# Altium schematic sheet Sheet1.SchDoc(Sheet1)
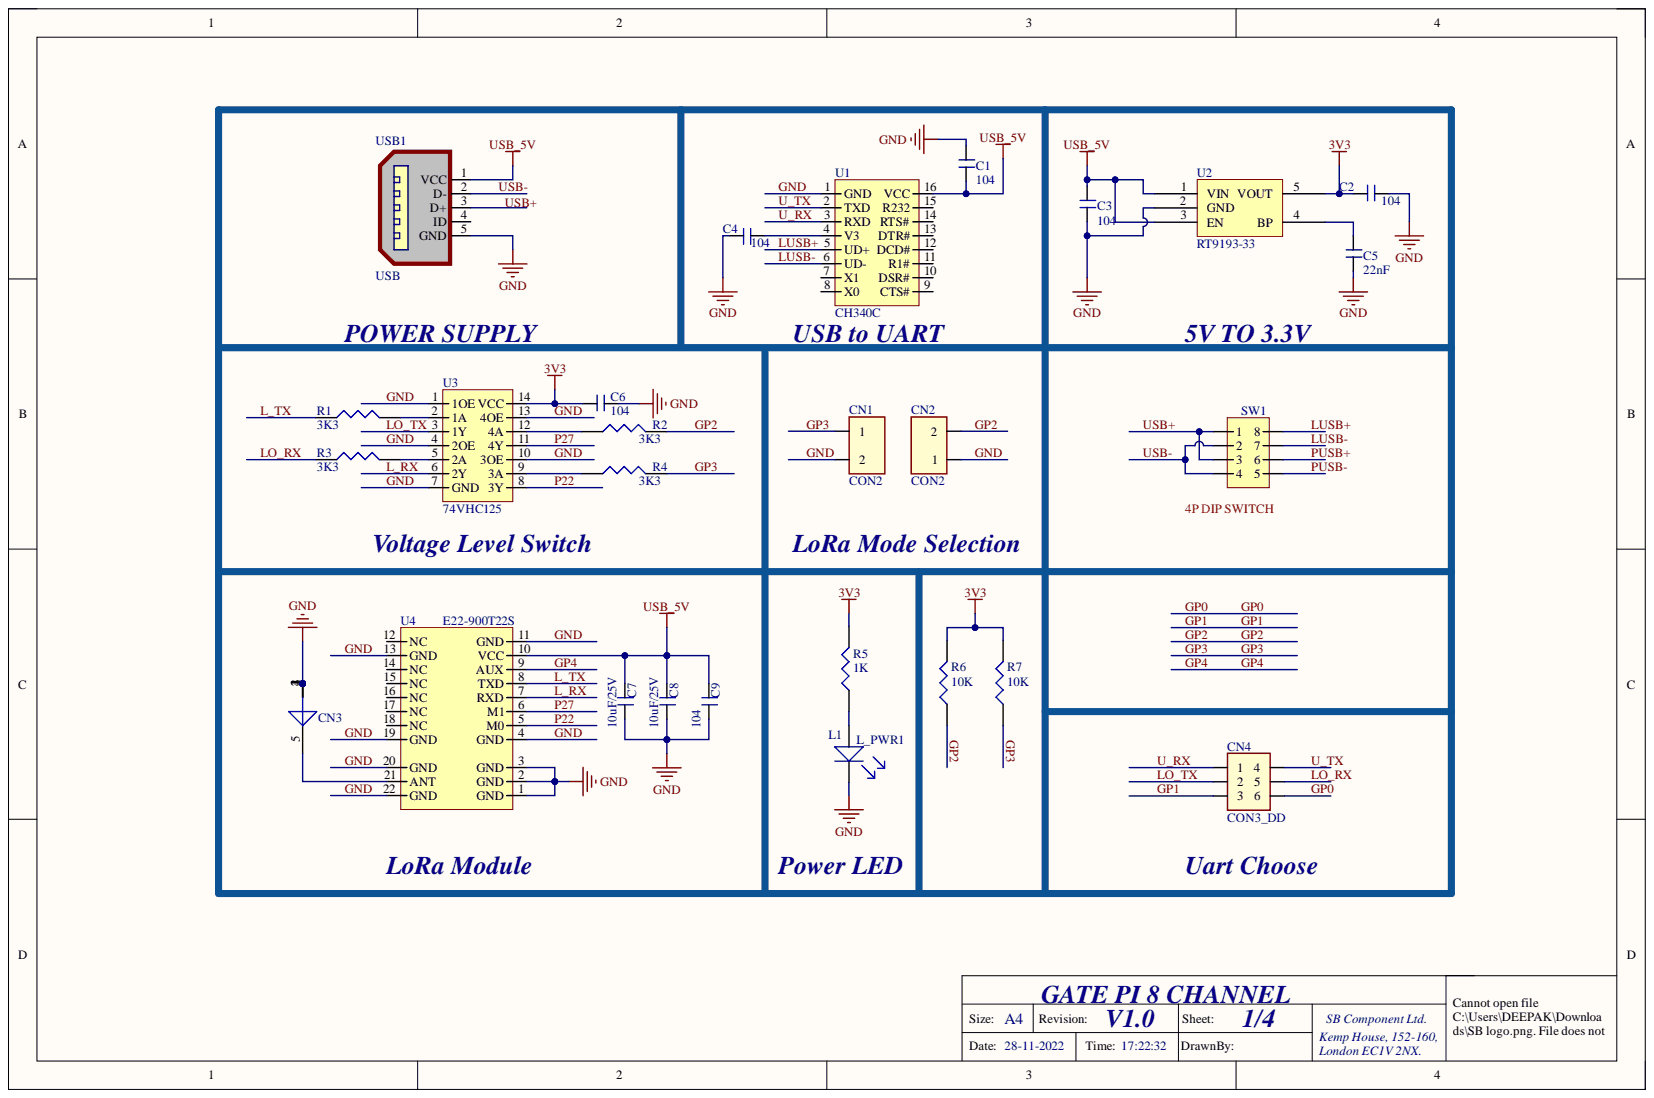
[diagram p0: Sheet1.SchDoc]

## Parts
C1  104  [CAP_0603_NONPOLAR]
C2  104  [CAP_0603_NONPOLAR]
C3  104  [CAP_0603_NONPOLAR]
C4  104  [CAP_0603_NONPOLAR]
C5  22nF  [CAP_0603_NONPOLAR]
C6  104  [CAP_0603_NONPOLAR]
C7  10uF/25V  [CAP_0603_NONPOLAR]
C8  10uF/25V  [CAP_0603_NONPOLAR]
C9  104  [CAP_0603_NONPOLAR]
CN1  CON2  [CON2_BJ]
CN2  CON2  [CON2_BJ]
CN3  SMA  CONNECTOR  [SMA_CONNECTOR]  (value not drawn)
CN4  CON3_DD  [CON3_BJ_DD]
L1  L_PWR1  [LED_0603_neg]
R1  3K3  [0603]
R2  3K3  [0603]
R3  3K3  [0603]
R4  3K3  [0603]
R5  1K  [0603]
R6  10K  [0603]
R7  10K  [0603]
SW1  SW_SLIDE_DIP 4 WAY  [SWITCH DIP 4 WAY 2.54P]  (value not drawn)
U1  CH340C  [SOIC_16]
U2  RT9193-33  [SOT-23-5]
U3  74VHC125  [SOT108_74HC126D]
U4  E22-900T22S  [E22-900T22S]
USB1  USB  [USB_MICRO]

## Nets
3V3: C2.2 C6.2 R5.2 R6.2 R7.2 U2.5(VOUT) U3.14(VCC)
USB_5V: C1.1 C3.2 C7.2 C8.2 C9.2 U1.16(VCC) U2.1(VIN) U2.3(EN) U4.10(VCC) USB1.1(VCC)
GND: C1.2 C2.1 C3.1 C4.1 C5.1 C6.1 C7.1 C8.1 C9.1 CN1.2 CN2.1 CN3.1 CN3.2 CN3.3 CN3.4 L1.K U1.1(GND) U2.2(GND) U3.1(1OE) U3.4(2OE) U3.7(GND) U3.10(3OE) U3.13(4OE) U4.1(GND) U4.2(GND) U4.3(GND) U4.4(GND) U4.11(GND) U4.13(GND) U4.19(GND) U4.20(GND) U4.22(GND) USB1.5(GND)
GP2: CN2.2 R2.2 R6.1
GP3: CN1.1 R4.2 R7.1
GP4: U4.9(AUX)
L_RX: U3.6(2Y) U4.7(RXD)
L_TX: R1.1 U4.8(TXD)
LO_RX: CN4.5 R3.1
LO_TX: CN4.2 U3.3(1Y)
LUSB+: SW1.8 U1.5(UD+)
LUSB-: SW1.7 U1.6(UD-)
P22: U3.8(3Y) U4.5(M0)
P27: U3.11(4Y) U4.6(M1)
P_RX: CN4.3
P_TX: CN4.6
PUSB+: SW1.6
PUSB-: SW1.5
U_RX: CN4.1 U1.3(RXD)
U_TX: CN4.4
USB+: SW1.1 SW1.3
USB-: SW1.2 SW1.4 USB1.2(D-)
NetC4_2: C4.2 U1.4(V3)
NetC5_2: C5.2 U2.4(BP)
NetCN3_5: CN3.5 U4.21(ANT)
NetL1_A: L1.A R5.1
NetR1_2: R1.2 U3.2(1A)
NetR2_1: R2.1 U3.12(4A)
NetR3_2: R3.2 U3.5(2A)
NetR4_1: R4.1 U3.9(3A)

Not connected: U1 2(TXD) 7(X1) 8(X0) 9(CTS#) 10(DSR#) 11(R1#) 12(DCD#) 13(DTR#) 14(RTS#) 15(R232); U4 12(NC) 14(NC) 15(NC) 16(NC) 17(NC) 18(NC); USB1 3(D+) 4(ID)

## Library data of the parts
C1-C9 = CAP_NONPOLAR: Component Kind: Standard; Library Name: dxp_pasl_lib.IntLib
CN3 = ANTENNA SMA CONNECTOR: Component Kind: Standard; Library Name: dxp_pasl_lib.IntLib
L1 = LED: Component Kind: Standard; Library Name: dxp_pasl_lib.IntLib
R1-R7 = RES: Component Kind: Standard; Library Name: dxp_pasl_lib.IntLib
SW1 = SW_SLIDE_DIP 4 WAY: SWITCH DIP 4 WAY 2.54P #C92645; Component Kind: Standard; Library Name: dxp_pasl_lib.IntLib
USB1 = USB_MICRO: Micro USB Connector SMD – TH Support Legs – 5 Pin – Type B; Component Kind: Standard; Library Name: dxp_pasl_lib.IntLib
CN1 CN2 = CON2: Component Kind: Standard; Library Name: dxp_pasl_lib.IntLib
CN4 = CON3_DD: Component Kind: Standard; Library Name: dxp_pasl_lib.IntLib
U1 = CH340C: Component Kind: Standard; Library Name: dxp_pasl_lib.IntLib
U2 = RT9193-33: Component Kind: Standard; Library Name: dxp_pasl_lib.IntLib
U3 = 74VHC125: Component Kind: Standard; Library Name: dxp_pasl_lib.IntLib
U4 = E22-900T22S: Component Kind: Standard; Library Name: dxp_pasl_lib.IntLib
